# Altium schematic sheet 06_NavigationPad.SchDoc
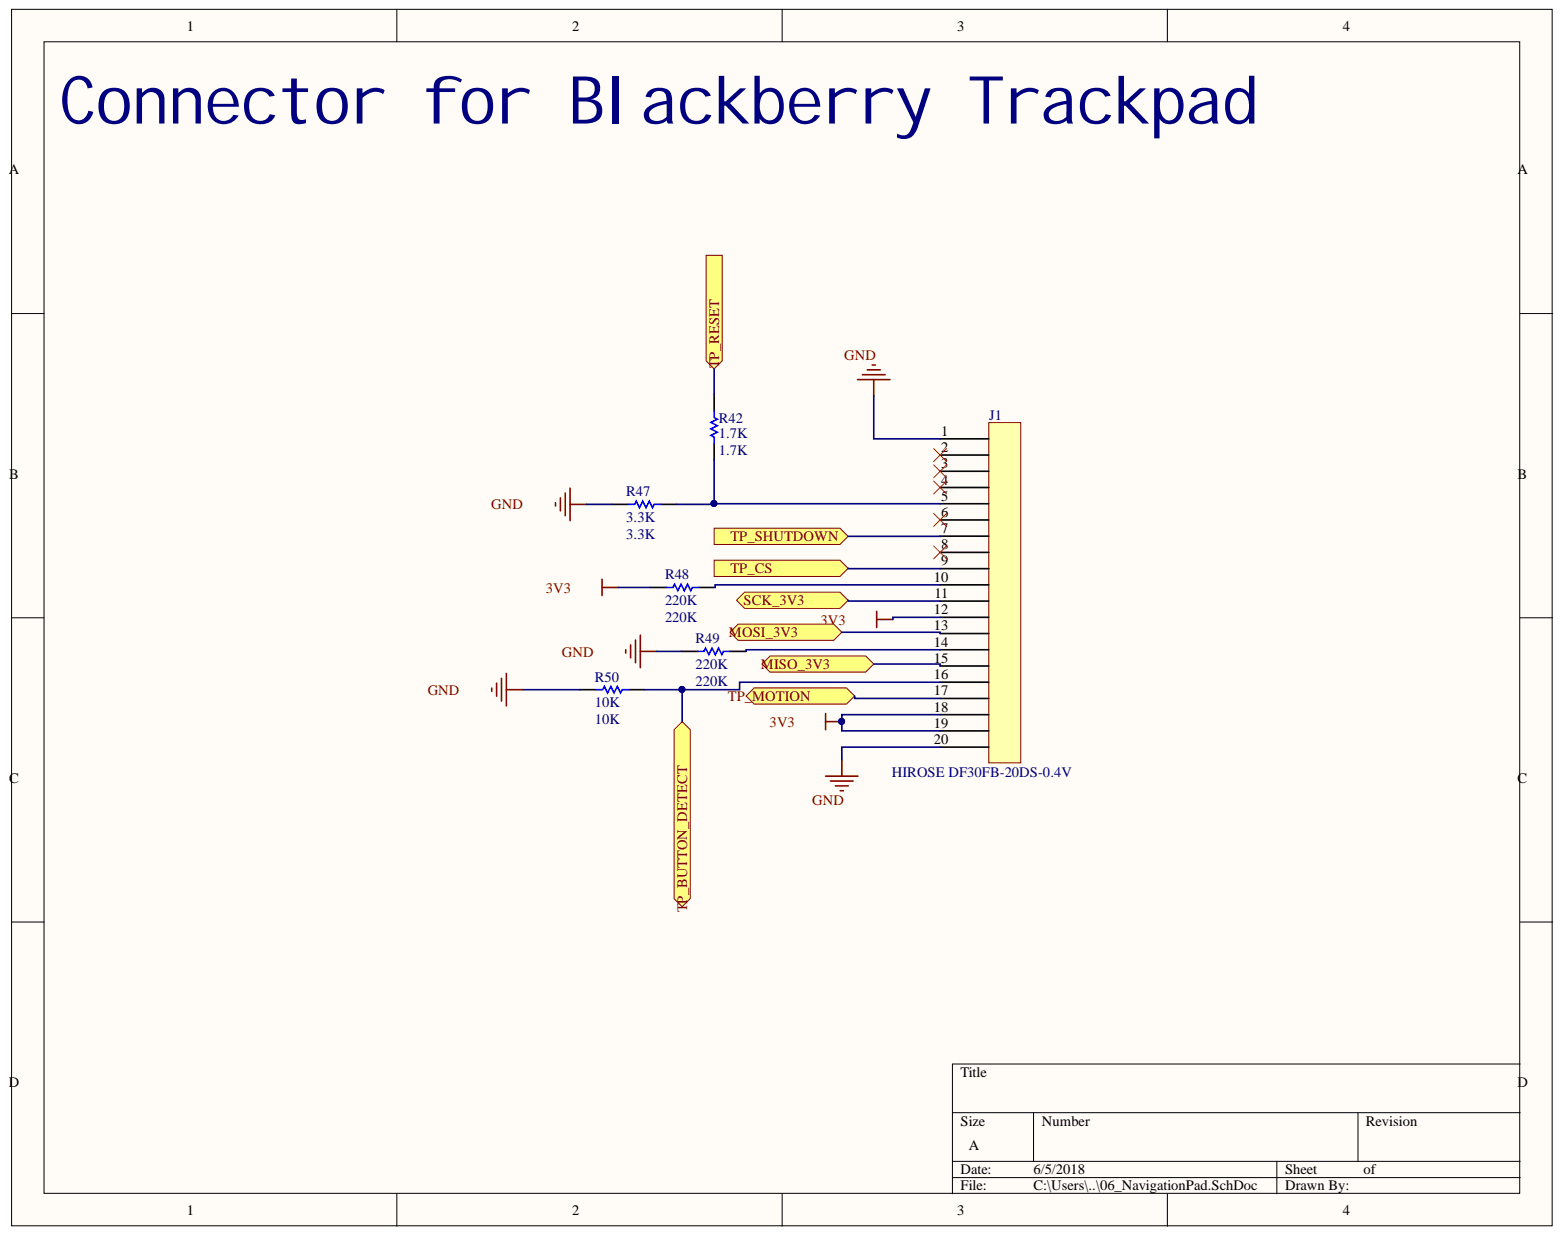
[diagram p0: 06_NavigationPad.SchDoc]

## Parts
J1  HIROSE DF30FB-20DS-0.4V  [HIROSE20]
R42  1.7K  [RES_0603_SMD]
R47  3.3K  [RES_0603_SMD]
R48  220K  [RES_0603_SMD]
R49  220K  [RES_0603_SMD]
R50  10K  [RES_0603_SMD]

## Ports
MISO_3V3, MOSI_3V3, SCK_3V3, TP_BUTTON_DETECT, TP_CS, TP_MOTION, TP_RESET, TP_SHUTDOWN

## Nets
3V3: J1.12 J1.18 J1.19 R48.2
GND: J1.1 J1.20 R47.2 R49.2 R50.2
MISO_3V3: J1.15 ; port MISO_3V3
MOSI_3V3: J1.13 ; port MOSI_3V3
SCK_3V3: J1.11 ; port SCK_3V3
TP_BUTTON_DETECT: J1.16 R50.1 ; port TP_BUTTON_DETECT
TP_CS: J1.9 ; port TP_CS
TP_MOTION: J1.17 ; port TP_MOTION
TP_RESET: R42.1 ; port TP_RESET
TP_SHUTDOWN: J1.7 ; port TP_SHUTDOWN
NetJ1_5: J1.5 R42.2 R47.1
NetJ1_10: J1.10 R48.1
NetJ1_14: J1.14 R49.1

Not connected: J1 2 3 4 6 8

## Library data of the parts
R42 R47-R50 = Res1: Resistor; Component Kind: Standard; LatestRevisionDate: 17-Jul-2002; LatestRevisionNote: Re-released for DXP Platform.; Library Name: Miscellaneous Devices.IntLib; PackageDescription: Axial Device, Thru-Hole; 2 Leads; 0.3 in Pin Spacing; PackageReference: AXIAL-0.3; Published: 8-Jun-2000; Signal Integrity: Res; Simulation: RESISTOR; Publisher: Altium Limited
  R42: Value: 1.7K
  R47: Value: 3.3K
  R48 R49: Value: 220K
  R50: Value: 10K
J1 = HIROSE DF30FB-20DS-0.4V: Component Kind: Standard; Library Name: ATmega2560_Library.SchLib
